# Altium schematic sheet Sheet1.SchDoc
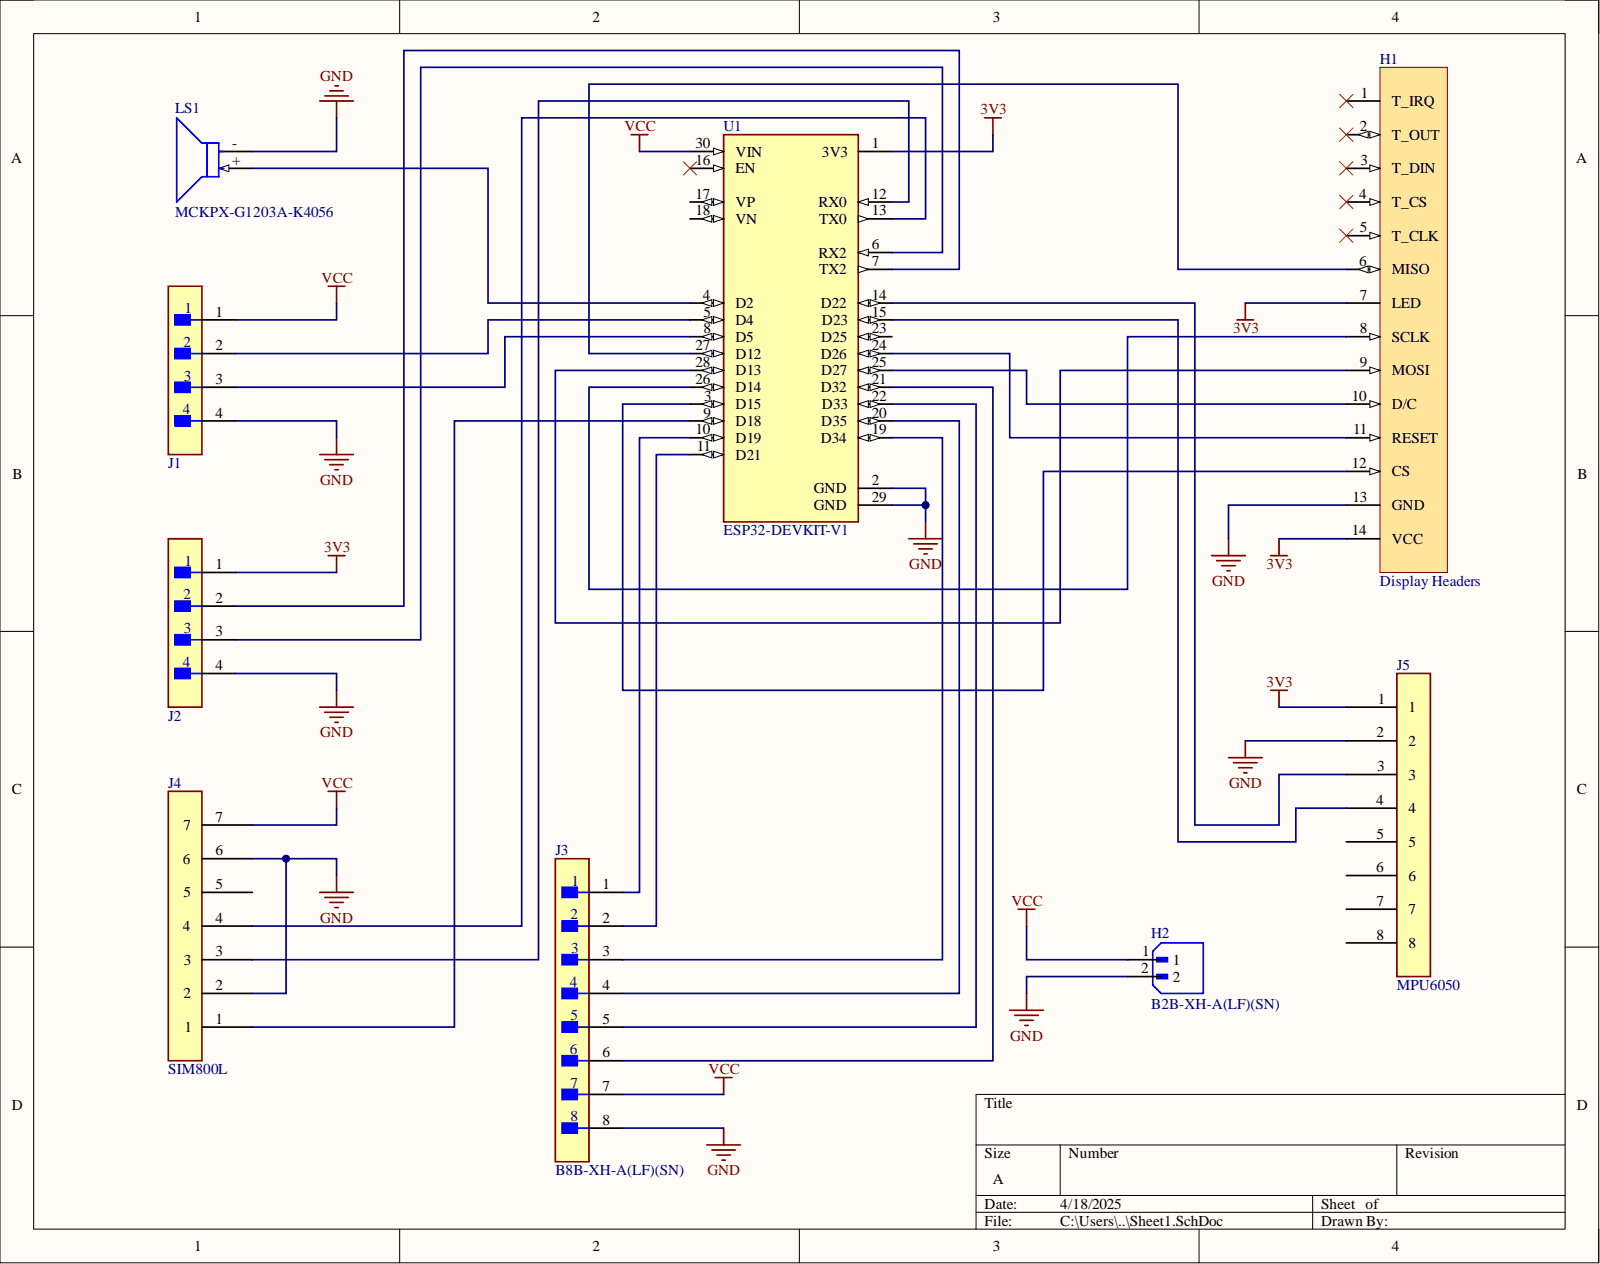
[diagram p0: Sheet1.SchDoc]

## Parts
H1  Display Headers  [SULLINS_PPTC061LFBN-RC]
H2  B2B-XH-A(LF)(SN)  [JST_B2B-XH-A(LF)(SN)]
J1  B04B-XASK-1(LF)(SN)  [FP-B04B-XASK-1_LF_SN-MFG]  (value not drawn)
J2  GPS  [GPS]  (value not drawn)
J3  B8B-XH-A(LF)(SN)  [FP-B8B-XH-A_LF_SN-MFG]
J4  SIM800L  [SIM800L]
J5  MPU6050  [MPU6050]
LS1  MCKPX-G1203A-K4056  [FP-MCKPX-G1203A-K4056-MFG - duplicate]
U1  ESP32-DEVKIT-V1  [MODULE_ESP32_DEVKIT_V1]

## Nets
3V3: H1.7(LED) H1.14(VCC) J2.1 J5.1 U1.1(3V3)
GND: H1.13(GND) H2.2 J1.4 J2.4 J3.8 J4.2 J4.6 J5.2 LS1.- U1.2(GND) U1.29(GND)
VCC: H2.1 J1.1 J3.7 J4.7 U1.30(VIN)
NetH1_6: H1.6(MISO) U1.27(D12)
NetH1_8: H1.8(SCLK) U1.26(D14)
NetH1_9: H1.9(MOSI) U1.28(D13)
NetH1_10: H1.10(D/C) U1.25(D27)
NetH1_11: H1.11(RESET) U1.24(D26)
NetH1_12: H1.12(CS) U1.3(D15)
NetJ1_2: J1.2 U1.5(D4)
NetJ1_3: J1.3 U1.8(D5)
NetJ2_2: J2.2 U1.7(TX2)
NetJ2_3: J2.3 U1.6(RX2)
NetJ3_1: J3.1 U1.10(D19)
NetJ3_2: J3.2 U1.11(D21)
NetJ3_3: J3.3 U1.19(D34)
NetJ3_4: J3.4 U1.20(D35)
NetJ3_5: J3.5 U1.22(D33)
NetJ3_6: J3.6 U1.21(D32)
NetJ4_1: J4.1 U1.9(D18)
NetJ4_3: J4.3 U1.12(RX0)
NetJ4_4: J4.4 U1.13(TX0)
NetJ5_3: J5.3 U1.14(D22)
NetJ5_4: J5.4 U1.15(D23)
NetLS1_+: LS1.+ U1.4(D2)

Not connected: H1 1(T_IRQ) 2(T_OUT) 3(T_DIN) 4(T_CS) 5(T_CLK); J4 5; J5 5 6 7 8; U1 16(EN) 17(VP) 18(VN) 23(D25)

## Library data of the parts
H1 = TFT-LCD-2.8'' Display Headers: Component Kind: Standard; Library Name: Schlib1.SchLib
H2 = B2B-XH-A(LF)(SN): Connector Header Through Hole 2 position 0.098 (2.50mm); MF: JST Sales America Inc.; Check_prices: https://www.snapeda.com/parts/B2B-XH-A(LF)(SN)/JST+Sales+America+Inc./view-part/?ref=eda; Component Kind: Standard; Library Name: Schlib1.SchLib; MP: B2B-XH-A(LF)(SN); Package: None; SnapEDA_Link: https://www.snapeda.com/parts/B2B-XH-A(LF)(SN)/JST+Sales+America+Inc./view-part/?ref=snap
J1 = CMP-17439-000018-1: CONN HEADER VERT 4POS 2.5MM; Manufacturer: JST; Conn Gender: Male; Conn Orientation: Straight; Current Rating: 3A; Number Of Contacts: 4; Voltage Rating: 250V; Automotive: No; Category: Conn; Component Kind: Standard; ComponentLink2Description: Datasheet; ComponentLink2URL: http://www.jst-mfg.com/product/pdf/eng/eXA1.pdf; Contact Material: Tin,Copper; Device Class L1: Connectors; Device Class L2: Headers and Wire Housings; Device Class L3: unset; Digikey Description: CONN HEADER VERT 4POS 2.5MM; Height: 8.8mm; Lead Free: Yes; Library Name: Altium Content Vault; Manufacturer Part Number: B04B-XASK-1(LF)(SN); Number Of Rows: 1; Octopart: https://octopart.com/b04b-xask-1%28lf%29%28sn%29-jst-3990149; Package: B04B-XASK-1(LF)(SN); Pitch: 2.5mm; RoHS: TRUE; Temperature: -25°C to +85°C; Temperature Range High: +85°C; Temperature Range Low: -25°C
J2 = GPS: Manufacturer: JST; Manufacturer Part Number: B04B-XASK-1(LF)(SN); Conn Gender: Male; Conn Orientation: Straight; Current Rating: 3A; Number Of Contacts: 4; Pitch: 2.5mm; Voltage Rating: 250V; Automotive: No; Category: Conn; Component Kind: Standard; ComponentLink2Description: Datasheet; ComponentLink2URL: http://www.jst-mfg.com/product/pdf/eng/eXA1.pdf; Contact Material: Tin,Copper; Device Class L1: Connectors; Device Class L2: Headers and Wire Housings; Device Class L3: unset; Digikey Description: CONN HEADER VERT 4POS 2.5MM; Height: 8.8mm; Lead Free: Yes; Library Name: Schlib1.SchLib; Number Of Rows: 1; Octopart: https://octopart.com/b04b-xask-1%28lf%29%28sn%29-jst-3990149; Package: B04B-XASK-1(LF)(SN); RoHS: TRUE; Temperature: -25°C to +85°C; Temperature Range High: +85°C; Temperature Range Low: -25°C
J3 = CMP-17438-000015-1: CONN HEADER VERT 8POS 2.5MM; Connector Type: Male Pin; Contact Resistance: 20mR; Current Rating: 3A; Insulation Resistance: 1GR; Max Voltage Rating (AC): 250V; Number of Contacts: 8; Wire/Cable Gauge: 22AWG; Color: Natural; Component Kind: Standard; Contact Material: Brass; Contact Plating: Tin; Contact Type: Male Pin; Depth: 5.75mm; Fastening Type: Detent; Housing Color: White; Housing Material: Polyamide; Lead Free: Lead Free; Lead Pitch: 2.5mm; Length: 22.4mm; Library Name: Altium Content Vault; Max Current Rating: 3A; Max Operating Temperature: 85°C; Min Operating Temperature: -25°C; Mount: Through Hole; Number of Positions: 8; Number of Rows: 1; Orientation: Straight; Packaging: Bulk; Pitch: 2.5mm; REACH SVHC: No SVHC; Series: XH; Termination: Press-Fit; Voltage Rating: 250V; Voltage Rating (AC): 250V
LS1 = MCKPX-G1203A-K4056: MAGNETIC BUZZER AND TRANSDUCER - More Details; Manufacturer: Multicomp Pro; Current: 30mA; Frequency: 2300Hz; Max Supply Voltage: 5V; Min Supply Voltage: 2V; Operating Supply Voltage: 3V; Standoff Height: 0.5mm; Automotive: No; Category: Speak; Component Kind: Standard; ComponentLink2Description: Datasheet; ComponentLink2URL: http://www.farnell.com/datasheets/1498149.pdf?_ga=2.17855225.1157923166.1572356775-536200964.1569240579; Device Class L1: Electromechanical; Device Class L2: Audio Products; Device Class L3: Buzzers; Height: 9.5mm; Lead Free: Yes; Library Name: Schlib1.SchLib; Manufacturer Part Number: MCKPX-G1203A-K4056; Octopart: https://octopart.com/mckpx-g1203a-k4056-multicomp-12157154; Package: 0; RoHS: TRUE; Temperature: -20°C to +70°C; Temperature Range High: +70°C; Temperature Range Low: -20°C
U1 = ESP32-DEVKIT-V1: Dual core, Wi-Fi: 2.4 GHz up to 150 Mbits/s,BLE (Bluetooth Low Energy) and legacy Bluetooth, 32 bits, Up to 240 MHz; MF: Do it; Check_prices: https://www.snapeda.com/parts/ESP32-DEVKIT-V1/Do+it/view-part/?ref=eda; Component Kind: Standard; Library Name: Schlib1.SchLib; MP: ESP32-DEVKIT-V1; Package: None; SnapEDA_Link: https://www.snapeda.com/parts/ESP32-DEVKIT-V1/Do+it/view-part/?ref=snap
J4 = SIM800L: Component Kind: Standard; Library Name: Schlib1.SchLib
J5 = MPU6050: Component Kind: Standard; Library Name: Schlib1.SchLib
